# Altium schematic sheet SPI_USB_SUPPLY_PWM.SchDoc
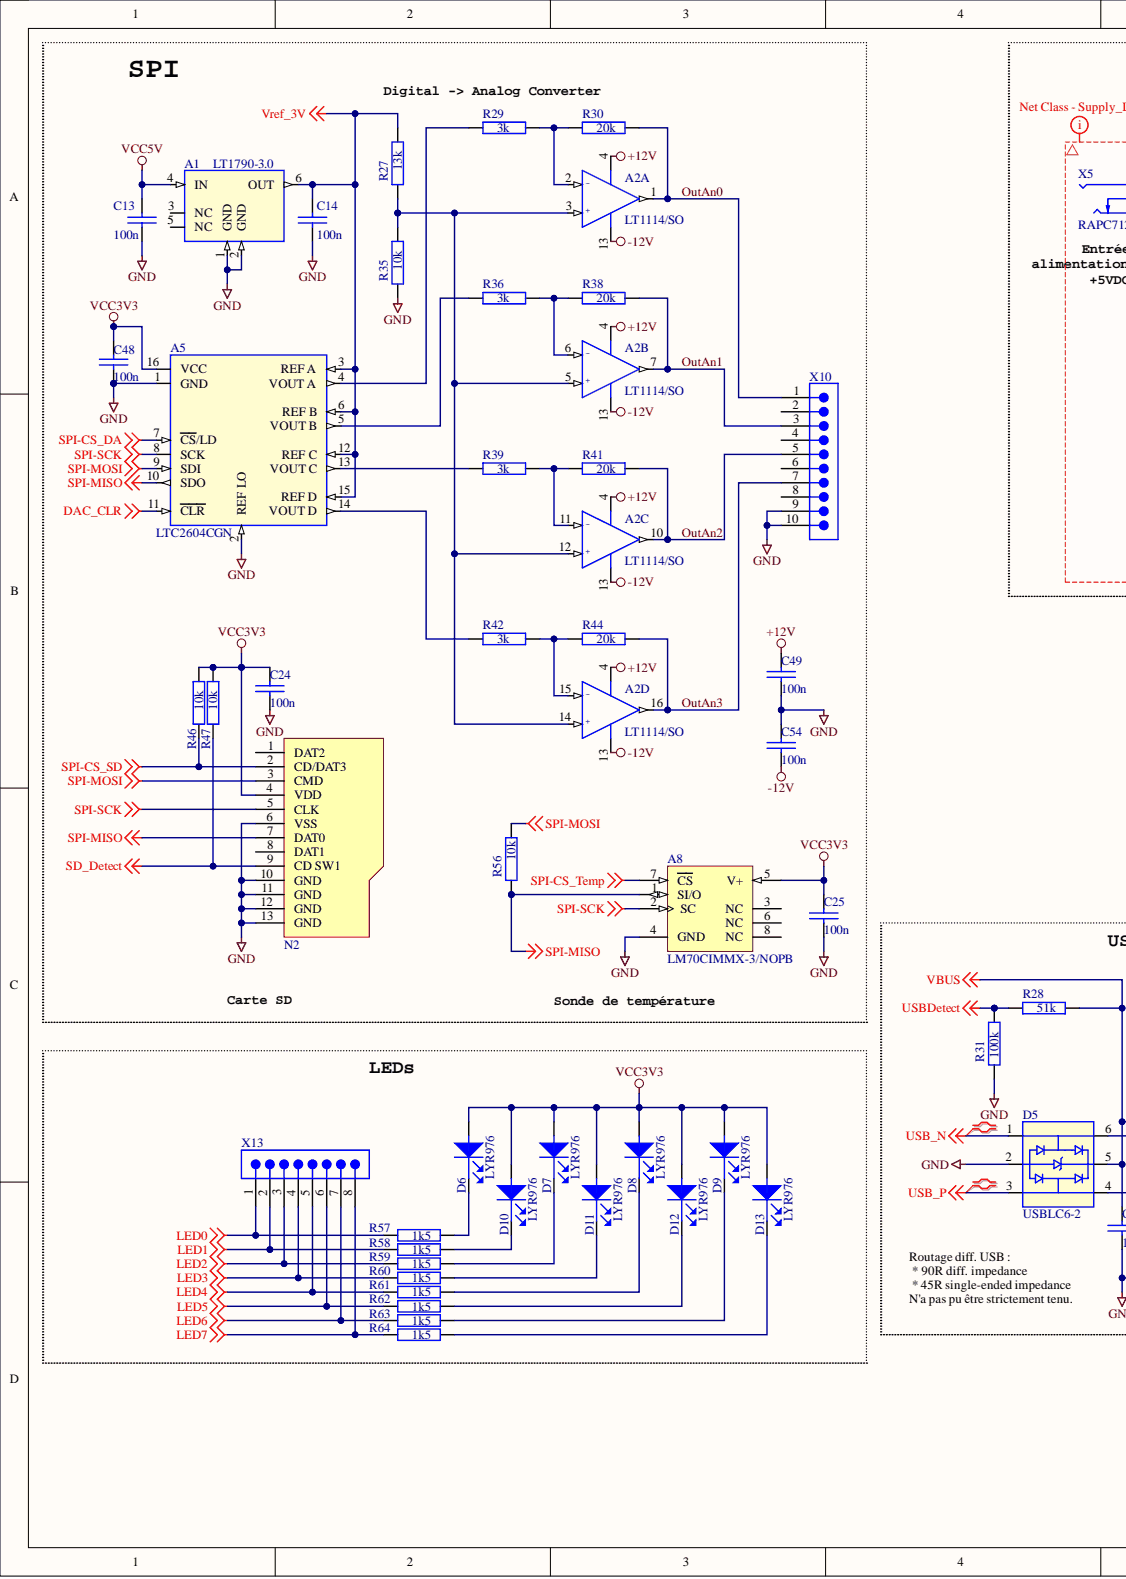
[diagram p0: SPI_USB_SUPPLY_PWM.SchDoc, left]
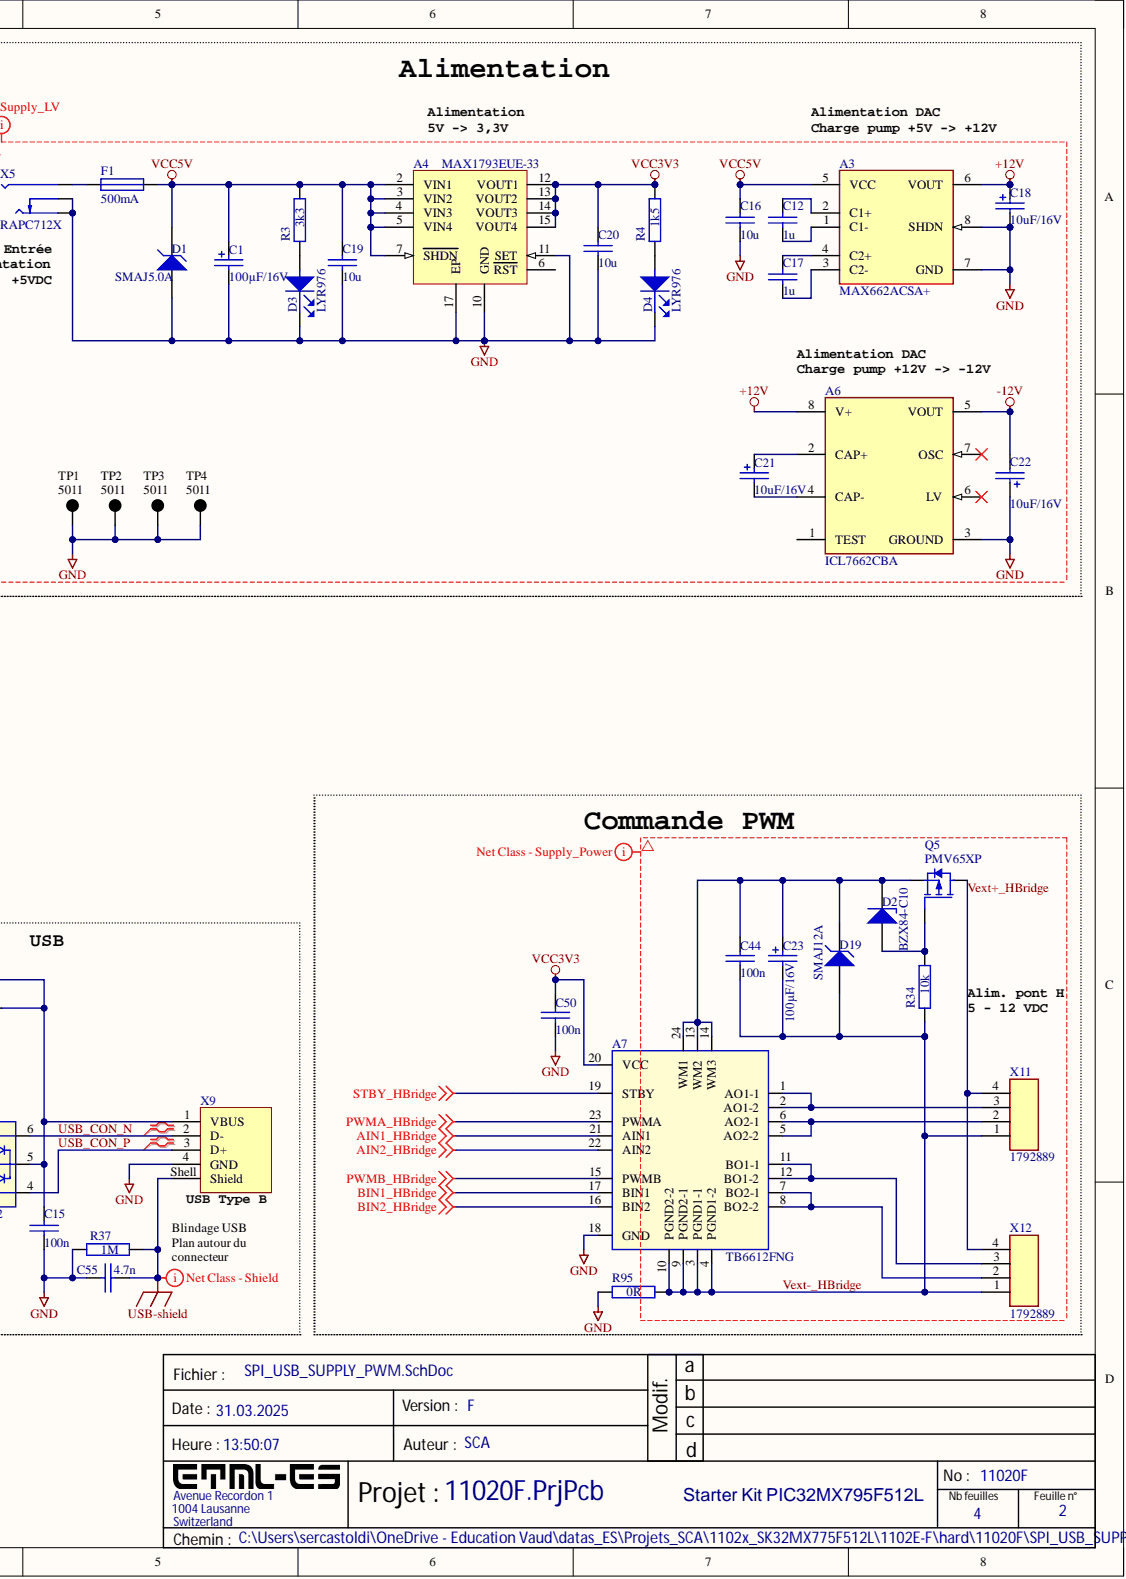
[diagram p1: SPI_USB_SUPPLY_PWM.SchDoc, right]

## Parts
A1  LT1790-3.0  [STM-SOT23-6L_V]
A2  LT1114/SO  [SOIC-16]  (parts A,B,C,D)
A3  MAX662ACSA+  [FP-S8-4-IPC_A]
A4  MAX1793EUE-33  [TSOP65P640X110_HS-17N]
A5  LTC2604CGN  [FP-GN-16-05-08-1641-IPC_A]
A6  ICL7662CBA  [FP-S8-4-IPC_A]
A7  TB6612FNG  [TOSH-SSOP-24_M]
A8  LM70CIMMX-3/NOPB  [DGK0008A_M]
C1  100µF/16V  [FP-FCE-MFG]
C12  1u  [CAPC0805(2012)145_N]
C13  100n  [CAPC0805(2012)145_N]
C14  100n  [CAPC0805(2012)145_N]
C15  100n  [CAPC0805(2012)145_N]
C16  10u  [CAPC0805(2012)145_N]
C17  1u  [CAPC0805(2012)145_N]
C18  10uF/16V  [FP-FCB-MFG]
C19  10u  [CAPC0805(2012)145_N]
C20  10u  [CAPC0805(2012)145_N]
C21  10uF/16V  [FP-FCB-MFG]
C22  10uF/16V  [FP-FCB-MFG]
C23  100µF/16V  [FP-FCE-MFG]
C24  100n  [CAPC0805(2012)145_N]
C25  100n  [CAPC0805(2012)145_N]
C44  100n  [CAPC0805(2012)145_N]
C48  100n  [CAPC0805(2012)145_N]
C49  100n  [CAPC0805(2012)145_N]
C50  100n  [CAPC0805(2012)145_N]
C54  100n  [CAPC0805(2012)145_N]
C55  4.7n  [CAPC0805(2012)145_N]
D1  SMAJ5.0A  [WE-TVSP_DO-214AC_Unidirectional]
D2  BZX84-C10  [FP-SOT23-IPC_A]
D3  LYR976  [LED_0805]
D4  LYR976  [LED_0805]
D5  USBLC6-2  [STM-SOT23-6L_V]
D6  LYR976  [LED_0805]
D7  LYR976  [LED_0805]
D8  LYR976  [LED_0805]
D9  LYR976  [LED_0805]
D10  LYR976  [LED_0805]
D11  LYR976  [LED_0805]
D12  LYR976  [LED_0805]
D13  LYR976  [LED_0805]
D19  SMAJ12A  [WE-TVSP_DO-214AC_Unidirectional]
F1  500mA  [FP-MF-SM050-2-MFG]
N2  693071020811  [693071020811]  (value not drawn)
Q5  PMV65XP  [FP-SOT23-IPC_A]
R3  3k3  [RESC0805(2012)_N]
R4  1k5  [RESC0805(2012)_N]
R27  13k  [RESC0805(2012)_N]
R28  51k  [RESC0805(2012)_N]
R29  3k  [RESC0805(2012)_N]
R30  20k  [RESC0805(2012)_N]
R31  100k  [RESC0805(2012)_N]
R34  10k  [RESC0805(2012)_N]
R35  10k  [RESC0805(2012)_N]
R36  3k  [RESC0805(2012)_N]
R37  1M  [RESC0805(2012)_N]
R38  20k  [RESC0805(2012)_N]
R39  3k  [RESC0805(2012)_N]
R41  20k  [RESC0805(2012)_N]
R42  3k  [RESC0805(2012)_N]
R44  20k  [RESC0805(2012)_N]
R46  10k  [RESC0805(2012)_N]
R47  10k  [RESC0805(2012)_N]
R56  10k  [RESC0805(2012)_N]
R57  1k5  [RESC0805(2012)_N]
R58  1k5  [RESC0805(2012)_N]
R59  1k5  [RESC0805(2012)_N]
R60  1k5  [RESC0805(2012)_N]
R61  1k5  [RESC0805(2012)_N]
R62  1k5  [RESC0805(2012)_N]
R63  1k5  [RESC0805(2012)_N]
R64  1k5  [RESC0805(2012)_N]
R95  0R  [RESC0805(2012)_N]
TP1  5011  [KSTN-5011_V]
TP2  5011  [KSTN-5011_V]
TP3  5011  [KSTN-5011_V]
TP4  5011  [KSTN-5011_V]
X5  RAPC712X  [SWITCHCRAFT_RAPC712X]
X9  Interface Type: Type B  [61400416121]  (hidden comment: 61400416121)
X10  61301011121  [61301011121]  (value not drawn)
X11  1792889  [1792889_CustomES]
X12  1792889  [1792889_CustomES]
X13  61300811121  [61300811121]  (value not drawn)

## Nets
+12V: A2.4 A3.6(VOUT) A6.8(V+) C18.2 C49.1
-12V: A2.13 A6.5(VOUT) C22.1 C54.2
AIN1_HBridge: A7.21(AIN1)
AIN2_HBridge: A7.22(AIN2)
BIN1_HBridge: A7.17(BIN1)
BIN2_HBridge: A7.16(BIN2)
DAC_CLR: A5.11(CLR)
GND: A1.1(GND) A1.2(GND) A3.7(GND) A3.8(SHDN) A4.10(GND) A4.11(SET) A4.17(EP) A5.1(GND) A5.2(REF_LO) A6.3(GROUND) A7.18(GND) A8.4(GND) C1.1 C13.2 C14.2 C15.2 C16.2 C18.1 C19.2 C20.2 C22.2 C24.2 C25.1 C48.2 C49.2 C50.2 C54.1 C55.1 D1.2 D3.1 D4.1 D5.2 N2.6(VSS) N2.10(GND) N2.11(GND) N2.12(GND) N2.13(GND) R31.1 R35.2 R37.1 R95.1 TP1.1 TP2.1 TP3.1 TP4.1 X5.SHUNT X5.SLEEVE X9.4(GND) X10.9 X10.10
LED0: R57.2 X13.1
LED1: R58.2 X13.2
LED2: R59.2 X13.3
LED3: R60.2 X13.4
LED4: R61.2 X13.5
LED5: R62.2 X13.6
LED6: R63.2 X13.7
LED7: R64.2 X13.8
PWMA_HBridge: A7.23(PWMA)
PWMB_HBridge: A7.15(PWMB)
SD_Detect: N2.9(CD_SW1) R47.2
SPI-CS_DA: A5.7(CS/LD)
SPI-CS_SD: N2.2(CD/DAT3) R46.2
SPI-CS_Temp: A8.7(CS)
SPI-MISO: A5.10(SDO) A8.1(SI/O) N2.7(DAT0) R56.1
SPI-MOSI: A5.9(SDI) N2.3(CMD) R56.2
SPI-SCK: A5.8(SCK) A8.2(SC) N2.5(CLK)
STBY_HBridge: A7.19(STBY)
USB-shield: C55.2 R37.2 X9.Shell(Shield)
USB_N: D5.1
USB_P: D5.3
USBDetect: R28.1 R31.2
VBUS: C15.1 D5.5 R28.2 X9.1(VBUS)
VCC3V3: A4.12(VOUT1) A4.13(VOUT2) A4.14(VOUT3) A4.15(VOUT4) A5.16(VCC) A7.20(VCC) A8.5(V+) C20.1 C24.1 C25.2 C48.1 C50.1 D6.2 D7.2 D8.2 D9.2 D10.2 D11.2 D12.2 D13.2 N2.4(VDD) R4.2 R46.1 R47.1
VCC5V: A1.4(IN) A3.5(VCC) A4.2(VIN1) A4.3(VIN2) A4.4(VIN3) A4.5(VIN4) A4.7(SHDN) C1.2 C13.1 C16.1 C19.1 D1.1 F1.1 R3.2
Vref_3V: A1.6(OUT) A5.3(REF_A) A5.6(REF_B) A5.12(REF_C) A5.15(REF_D) C14.1 R27.1
OutAn0: A2.1 R30.2 X10.1
OutAn1: A2.7 R38.2 X10.3
OutAn2: A2.10 R41.2 X10.5
OutAn3: A2.16 R44.2 X10.7
USB_CON_N: D5.6 X9.2(D-)
USB_CON_P: D5.4 X9.3(D+)
Vext+_HBridge: Q5.3 X11.4 X12.4
Vext-_HBridge: A7.3(PGND1-1) A7.4(PGND1-2) A7.9(PGND2-1) A7.10(PGND2-2) C23.1 C44.2 D19.2 R34.2 R95.2 X11.1 X12.1
NetA2_2: A2.2(-) R29.2 R30.1
NetA2_3: A2.3(+) A2.5(+) A2.12(+) A2.14(+) R27.2 R35.1
NetA2_6: A2.6(-) R36.2 R38.1
NetA2_11: A2.11(-) R39.2 R41.1
NetA2_15: A2.15(-) R42.2 R44.1
NetA3_1: A3.1(C1-) C12.1
NetA3_2: A3.2(C1+) C12.2
NetA3_3: A3.3(C2-) C17.1
NetA3_4: A3.4(C2+) C17.2
NetA5_4: A5.4(VOUT_A) R29.1
NetA5_5: A5.5(VOUT_B) R36.1
NetA5_13: A5.13(VOUT_C) R39.1
NetA5_14: A5.14(VOUT_D) R42.1
NetA6_2: A6.2(CAP+) C21.2
NetA6_4: A6.4(CAP-) C21.1
NetA7_1: A7.1(AO1-1) A7.2(AO1-2) X11.3
NetA7_5: A7.5(AO2-2) A7.6(AO2-1) X11.2
NetA7_7: A7.7(BO2-1) A7.8(BO2-2) X12.2
NetA7_11: A7.11(BO1-1) A7.12(BO1-2) X12.3
NetA7_13: A7.13(WM2) A7.14(WM3) A7.24(WM1) C23.2 C44.1 D2.3 D19.1 Q5.2
NetD2_1: D2.1 Q5.1 R34.1
NetD3_2: D3.2 R3.1
NetD4_2: D4.2 R4.1
NetD6_1: D6.1 R57.1
NetD7_1: D7.1 R59.1
NetD8_1: D8.1 R61.1
NetD9_1: D9.1 R63.1
NetD10_1: D10.1 R58.1
NetD11_1: D11.1 R60.1
NetD12_1: D12.1 R62.1
NetD13_1: D13.1 R64.1
NetF1_2: F1.2 X5.PIN

Not connected: A1 3(NC) 5(NC); A4 6(RST); A6 1(TEST) 6(LV) 7(OSC); A8 3(NC) 6(NC) 8(NC); N2 1(DAT2) 8(DAT1); X10 2 4 6 8

## Library data of the parts
A1 = LT1790: Component Kind: Standard; Library Name: 11020E.SCHLIB
A3 = CMP-05722-000026-1: IC REG CHARG PUMP 12V 30MA 8SOIC; Manufacturer: Maxim Integrated; Max Power Dissipation: 471mW; Max Supply Voltage: 5.5V; Min Supply Voltage: 4.5V; Nominal Supply Current: 185uA; Number Of Outputs: 1; Output Type: Other; Standoff Height: 0.1mm; Switching Frequency: 500kHz; Switching Topology: Charge Pump; Automotive: No; Category: IC; Component Kind: Standard; ComponentLink2Description: Datasheet; ComponentLink2URL: https://datasheets.maximintegrated.com/en/ds/MAX662A.pdf; Device Class L1: Integrated Circuits (ICs); Device Class L2: Power Management ICs; Device Class L3: Voltage Regulators - Switching; Digikey Description: IC REG CHARG PUMP 12V 30MA 8SOIC; Footprint Url: https://pdfserv.maximintegrated.com/land_patterns/90-0096.PDF; Height: 1.75mm; Lead Free: Yes; Library Name: Altium Content Vault; Manufacturer Part Number: MAX662ACSA+; Mouser Description: Voltage Regulators - Switching Regulators 12V 30mA Flash Programming Supply; Octopart: https://octopart.com/max662acsa%2B-maxim+integrated-39398572; Output Current: 30mA; Output Voltage: 12V; Package: S8-5; RoHS: TRUE; Temperature: 0°C to +70°C; Temperature Range High: +70°C; Temperature Range Low: 0°C
A4 = MAX1793EUE-33: MAX1793 linear regulator 3.3V; Code_JEDEC: MO-178-AB; Component Kind: Standard; ComponentLink1Description: Manufacturer URL; ComponentLink1URL: http://www.maxim-ic.com; ComponentLink2Description: Datasheet; ComponentLink2URL: https://datasheets.maximintegrated.com/en/ds/MAX1793.pdf; ComponentLink3Description: Package Specification; Library Name: KitArmM0.SchLib; PackageDescription: TSSOP16+exposed pad; PackageReference: http://pdfserv.maxim-ic.com/package_dwgs/21-0108.PDF; Supplier: Digikey,Stock ES; Supplier min. qty: 1; Supplier Ref.: MAX1793EUE33+-ND; Supplier unit price: 3.88; Value: MAX1793EUE-33
A5 = LTC2604: Integral Nonlinearity (INL): 64LSB; Interface: Serial; Max Power Dissipation: 10mW; Max Supply Voltage: 5.5V; Min Supply Voltage: 2.5V; Nominal Supply Current: 1.3mA; Number of Bits: 16; Number of Channels: 4; Operating Supply Voltage: 5V; Output Polarity: Unipolar; Output Type: Voltage; Resolution: 16b; Settling Time: 10us; Supply Type: Single; Case/Package: SSOP; Component Kind: Standard; Height: 1.5mm; Lead Free: Lead Free; Length: 4.98mm; Max Operating Temperature: 70°C; Min Operating Temperature: 0°C; Mount: Surface Mount; Number of Converters: 4; Number of DAC Channels: 4; Number of Pins: 16; Package Quantity: 100; Packaging: Rail/Tube; Polarity: Unipolar; Width: 3.99mm
A6 = CMP-05722-000092-1: IC REG CHARGE PUMP INV 8SOIC; Manufacturer: Maxim Integrated; Manufacturer Part Number: ICL7662CBA+T; Max Power Dissipation: 500mW; Max Supply Voltage: 20V; Min Supply Voltage: 4.5V; Negative Voltage Available: true; Nominal Supply Current: 20-250uA; Number Of Outputs: 1; Output Current: 20mA; Output Type: Fixed; Output Voltage: -20/+40V; Standoff Height: 0.1mm; Switching Frequency: 10kHz; Automotive: No; Category: IC; Component Kind: Standard; ComponentLink2Description: Datasheet; ComponentLink2URL: https://datasheets.maximintegrated.com/en/ds/ICL7662-Si7661.pdf; Device Class L1: Integrated Circuits (ICs); Device Class L2: Power Management ICs; Device Class L3: Voltage Regulators - Switching; Digikey Description: IC REG CHARGE PUMP INV 8SOIC; Footprint Url: https://pdfserv.maximintegrated.com/land_patterns/90-0096.PDF; Height: 1.75mm; Lead Free: Yes; Library Name: Altium Content Vault; Mouser Description: Switching Voltage Regulators CMOS Voltage Converter; Octopart: https://octopart.com/icl7662cba%2Bt-maxim+integrated-39621882; Package: S8-4; RoHS: TRUE; Switching Topology: Charge Pump; Temperature: 0°C to +70°C; Temperature Range High: +70°C; Temperature Range Low: 0°C
A8 = SEN-TEMP-LM70CIMM-8: SPI/10-Bit plus Sign Digital Temperature Sensor, 8-pin Mini SOIC, Pb-Free; Manufacturer: Texas Instruments; CompType: Temp Sensor IC; PackageTopMarking: ZXTT*T04C; PowerWise: Y; Code_JEDEC: MO-187-AA; Component Kind: Standard; ComponentLink1Description: Datasheet URL; ComponentLink1URL: http://www.ti.com/general/docs/lit/getliterature.tsp?genericPartNumber=LM70&fileType=pdf; Library Name: 11020E.SCHLIB; PackageDescription: MINI SOIC, .118 x .118 x .034in, 8 Lead, .0256in Pitch; PackageReference: DGK0008A; PinCount: 8; RoHS: Y
C1 C23 = C_POL: EEE-FC1C101P Aluminum Electrolytic Capacitor, 100 uF, 16 V, ± 20%, 1000 hours @ 105°C, Radial Can - SMD; NexarPartId: 39481103; Capacitance: 100µF; Dielectric Material: Aluminium; ESR (Equivalent Series Resistance): 400mΩ; Lead Length: 3.4mm; Leakage Current: 3µA; Polarity: Polar; Ripple Current: 230mA; Voltage: 16V; Case/Package: Radial; Component Kind: Standard; Construction: Cylindrical; Contact Plating: Tin; Diameter: 8mm; Height: 6.2mm; Height - Seated (Max): 6.1976mm; Impedance: 400mΩ; Lead Free: Lead Free; Lead Pitch: 2.2mm; Length: 6.5mm; Library Name: 11020E.SCHLIB; Life (Hours): 1000hours; Lifecycle Status: Production; Material: Aluminium; Max Operating Temperature: 105°C; Min Operating Temperature: -40°C; Mount: PCB, Surface Mount; Number of Pins: 2; Packaging: Cut Tape; Radiation Hardening: No; REACH SVHC: No SVHC; Ripple Current (AC): 230mA; RoHS: Compliant; Schedule B: 8532220020, 8532220020; Supplier: Stock ES; Termination: SMD/SMT; Tolerance: 20%; Voltage Rating: 16V; Voltage Rating (AC): 16V; Voltage Rating (DC): 16V; Width: 8.3mm
C12-C17 C19 C20 C24 C25 C44 C48-C50 C54 C55 = C: Component Kind: Standard
  C12 C17: Value: 1u
  C13-C15 C24 C25 C44 C48-C50 C54: Value: 100n
  C16 C19 C20: Value: 10u
  C55: Value: 4.7n
C18 C21 C22 = C_POL: EEE-FC1C100R CAP ALUM 10UF 20% 16V SMD; Dielectric Material: Aluminium; ESR (Equivalent Series Resistance): 3R; Lead Length: 1.8mm; Leakage Current: 3uA; Life (Hours): 1000Hour; Ripple Current: 60mA; Capacitance: 10uF; Case/Package: Radial; Component Kind: Standard; Construction: Cylindrical; Contact Plating: Tin; Diameter: 4mm; Height: 5.4mm; Height - Seated (Max): 0.213inch; Impedance: 3R; Lead Free: Lead Free; Lead Pitch: 1mm; Length: 5.5mm; Library Name: 11020E.SCHLIB; Material: Aluminium; Max Operating Temperature: 105°C; Min Operating Temperature: -40°C; Mount: Surface Mount; Number of Pins: 2; Package Quantity: 2000; Packaging: Tape and Reel; Radiation Hardening: No; Ratings: AEC-Q200; Reach SVHC Compliant: Yes; Ripple Current (AC): 60mA; RoHS Compliant: Yes; Series: FC; Supplier: Stock ES; Termination: SMD/SMT; Tolerance: 20%; Voltage: 16V; Voltage Rating: 16V; Voltage Rating (DC): 16V; Width: 4.3mm
D1 = 824500500: WE-TVSP SMT Power TVS Diode, DO-214AC, Unidirectional, 400W, 5VDC; Manufacturer: Wurth Elektronik; (Reverse) Breakdown Voltage: 6.7V; (Reverse) Peak Pulse Current: 43.5A; Clamping Voltage: 9.2V; Operating Voltage (DC): 5V; Case/Size Code: DO-214AC; Category: Surge Protection; Component Kind: Standard; ComponentLink1Description: Website Link; ComponentLink1URL: https://www.we-online.com/redexpert/article/824500500?ad; ComponentLink2Description: Datasheet Link; ComponentLink2URL: https://www.we-online.com/redexpert/spec/824500500?ad; Height: 2.25mm; Length: 4.24mm; Library Name: Altium_WE-TVSP (rev22b).IntLib; Manufacturer Part Number: 824500500; Match Code: WE-TVSP; Mount: Surface Mount; Operating Temperature Max: 150°C; Operating Temperature Min: -65°C; Packaging: Tape and Reel; Polarity: Unidirectional; Power Dissipation: 400W; Width: 2.67mm
D2 = D_ZENER: Zener Diode 10V 250mW ±5% Surface Mount TO-236AB; Manufacturer: Nexperia; Manufacturer Part Number: BZX84-C10,215; PartNumber: LGR971-KN-1; Automotive Grade: Grade 0; Color: Green; Forward Current: 200mA; Max Junction Temp: +150°C; Max Reverse Leakage Current: 0.2uA; Max Zener Voltage: 10.6V; Reverse Voltage: 7V; Value: LGR971-KN-1; Zener Impedance: 8Ω; Automotive: Yes; Category: Diode; Component Kind: Standard; ComponentLink1Description: Manufacturer URL; ComponentLink2Description: Datasheet; ComponentLink2URL: https://assets.nexperia.com/documents/data-sheet/BZX84_SER.pdf; Device Class L1: Discrete Semiconductors; Device Class L2: Diodes; Device Class L3: Zener Diodes; Digikey Description: DIODE ZENER 10V 250MW SOT23; Footprint Url: https://assets.nexperia.com/documents/outline-drawing/SOT23.pdf; Height: 1.1mm; Ipc Land Pattern Name: SOT95P230X100-3; Lead Free: Yes; Library Name: 11020E.SCHLIB; Mouser Description: Diode Zener Single 10 Volt 5% 250m Watt Automotive 3-Pin SOT-23; Nom Zener Voltage: 10V; Octopart: https://octopart.com/bzx84-c10%2C215-nexperia-78740221; Package: SOT23; PackageReference: 0805_A; Power Dissipation: 250mW; RoHS: TRUE; Supplier: Stock ES; Temperature: -65°C to +150°C; Temperature Range High: +150°C; Temperature Range Low: -65°C
D3 D4 D6-D13 = LED_0: LED YELLOW DIFFUSED 0805 SMD; Lens Color: White; Lens Style: Flat; Case Code (Imperial): 0805; Case Code (Metric): 2012; Case/Package: 0805; Color: Yellow; Component Kind: Standard; Illumination Color: Yellow; Library Name: 11020E.SCHLIB; Mount: Surface Mount
D19 = 824500121: WE-TVSP SMT Power TVS Diode, DO-214AC, Unidirectional, 400W, 12VDC; Manufacturer: Wurth Elektronik; (Reverse) Breakdown Voltage: 14V; (Reverse) Peak Pulse Current: 20.1A; Clamping Voltage: 19.9V; Operating Voltage (DC): 12V; Case/Size Code: DO-214AC; Category: Surge Protection; Component Kind: Standard; ComponentLink1Description: Website Link; ComponentLink1URL: https://www.we-online.com/redexpert/article/824500121?ad; ComponentLink2Description: Datasheet Link; ComponentLink2URL: https://www.we-online.com/redexpert/spec/824500121?ad; Height: 2.25mm; Length: 4.24mm; Library Name: Altium_WE-TVSP (rev22b).IntLib; Manufacturer Part Number: 824500121; Match Code: WE-TVSP; Mount: Surface Mount; Operating Temperature Max: 150°C; Operating Temperature Min: -65°C; Packaging: Tape and Reel; Polarity: Unidirectional; Power Dissipation: 400W; Width: 2.67mm
F1 = F: MF-SM050-2 / PTC RESET FUSE 60V 500MA 2SMD; Manufacturer: Bourns; Automotive Grade: Grade 3; Current Rating: 40A; Hold Current: 0.5A; Resistance: 0.35-1.4Ω; Standoff Height: 0.66mm; Trip Current: 1A; Trip Time: 4s; Automotive: Yes; Category: Fuse; Component Kind: Standard; ComponentLink2Description: Datasheet; ComponentLink2URL: https://www.bourns.com/docs/Product-Datasheets/mfsm.pdf; Device Class L1: Circuit Protection; Device Class L2: PTC Resettable Fuses; Device Class L3: unset; Digikey Description: PTC RESET FUSE 60V 500MA 2SMD; Height: 3.18mm; Lead Free: Yes; Library Name: 11020E.SCHLIB; Manufacturer Part Number: MF-SM050-2; Mouser Description: PTC Resettable Fuse 0.5A(hold) 1A(trip) 60V 40A 1.7W 4s 0.35Ohm SMD Solder Pad 7.98 X 5.44 X 3.18mm T/R; Octopart: https://octopart.com/mf-sm050-2-bourns-37815; Package: MF-SM050-2; RoHS: TRUE; Temperature: -40°C to +85°C; Temperature Range High: +85°C; Temperature Range Low: -40°C; Value: 500mA; Voltage Rating: 60V
N2 = 693071020811: WR-CRD Micro SD Card Connector Push & Push; Manufacturer: Wurth Elektronik; Contact Resistance: 100mOhm; Gender: Socket; Rated Current: 0.5A; Withstanding Voltage: 500VAC; Working Voltage: 30VAC; Category: Input/Output Connectors; Component Kind: Standard; ComponentLink1Description: Website Link; ComponentLink1URL: https://www.we-online.com/redexpert/article/693071020811?ad; ComponentLink2Description: Datasheet Link; ComponentLink2URL: https://www.we-online.com/redexpert/spec/693071020811?ad; Library Name: Altium_WR-CRD (rev23b).IntLib; Manufacturer Part Number: 693071020811; Match Code: WR-CRD; Mount: Surface Mount; Number of Pins: 8; Operating Temperature Max: 85°C; Operating Temperature Min: -40°C; Packaging: Tape and Reel; Type: Push & Push
Q5 = PEMOS-GSD-3_A: Single P-Channel Trench MOSFET, -20 V, -55 to 150 degC, 3-Pin SOT23, Tape and Reel; Manufacturer: NXP Semiconductors; PartNumber: PMV65XP,215; Channel Type: P; Ciss [typ] (pF): 744; Continuous Drain Current Id: 1.2A; Coss [typ] (pF): 65; Current Temperature: 25degC; Drain Source Voltage Vds: 20V; External Depth: 2.5mm; External Length / Height: 1.12mm; External Width: 3.05mm; Junction Temperature Tj Min: -55degC; No. of Pins: 3; No. of Transistors: 1; On Resistance Rds(on): 250mohm; Packing: Tape & Reel; Power Dissipation Pd: 540mW; Pulse Current Idm: 7.4A; Rds(on) Test Voltage Vgs: 4.5V; Reel Quantity: 3000; SMD Marking: 1A; SVHC: NoSVHC(19-Dec-2012); Threshold Voltage Vgs Typ: 700mV; Transistor Case Style: SOT-23; Transistor Polarity: NChannel; VGSth [typ] (V): -0.65; Code_JEDEC: TO-236AB; Component Kind: Standard; ComponentLink1Description: Manufacturer URL; ComponentLink1URL: http://www.nxp.com/; ComponentLink2Description: Datasheet; ComponentLink2URL: http://www.nxp.com/documents/data_sheet/PMV65XP.pdf; ComponentLink3Description: Package Specification; ComponentLink3URL: http://www.nxp.com/documents/outline_drawing/sot023_po.pdf; Current Id Max: 1.2A; Datasheet URL: https://datasheet.ciiva.com/29326/162826-da-01-en-irlml-2402tr-29326791.pdf; Datasheet Version: Jan-03; DatasheetVersion: Rev. 2; Full Power Rating Temperature: 25degC; Library Name: 11020E.SCHLIB; Manufacturer URL: http://internationalrectifier.com/; Mounting Technology: Surface Mount; Operating Temperature Range: -55degCto+150degC; Package / Case: SOT-23; PackageDescription: 3-Pin Small Outline Transistor Package, Body 2.9 x 1.3 mm, Pitch 0.95 mm; PackageReference: SOT23; PackageVersion: 06-03-16, 03/2006; Tape Width: 8mm; Termination Type: SMD; VDS [max] (V): -20; Voltage Vds Typ: 20V; Voltage Vgs Max: 700mV; Voltage Vgs Rds on Measurement: 4.5V; Voltage Vgs th Min: 700mV
R3 R4 R27-R31 R34-R39 R41 R42 R44 R46 R47 R56-R64 R95 = R: Component Kind: Standard
  R3: Value: 3k3
  R4 R57-R64: Value: 1k5
  R27: Value: 13k
  R28: Value: 51k
  R29 R36 R39 R42: Value: 3k
  R30 R38 R41 R44: Value: 20k
  R31: Value: 100k
  R34 R35 R46 R47 R56: Value: 10k
  R37: Value: 1M
  R95: Value: 0R
TP1-TP4 = CMP-1672-00003-4: Test Point, Black, Through Hole, RoHS, Bulk; Manufacturer: Keystone; Colour: Black; Component Kind: Standard; ComponentLink1Description: Manufacturer URL; ComponentLink1URL: http://www.keyelco.com/; ComponentLink2Description: Datasheet; ComponentLink2URL: http://www.keyelco.com/product-pdf.cfm?p=1320; Contact Material: Bronze; Contact Plating: Silver; DatasheetVersion: Rev. F, 12/2013; Height: 8.12 mm; Library Name: Altium Content Vault; Mount: Through Hole; Mounting Technology: Through Hole; Package Quantity: 100; PackageDescription: 1-Pin Through Hole Device, Dia 3.17 mm; PackageReference: 5011; Packaging: Bulk; Pins: 1; REACH SVHC: No SVHC; RoHSCompliant: true
X5 = RAPC712X: Power Barrel Connector Jack 2.50mm ID (0.098), 5.50mm OD (0.217) Through Hole, Right Angle; MF: Switchcraft; Availability: In Stock; Check_prices: https://www.snapeda.com/parts/RAPC712X/Switchcraft+Inc./view-part/?ref=eda; Component Kind: Standard; Library Name: 11020E.SCHLIB; MP: RAPC712X; Package: None; Price: None; Purchase-URL: https://pricing.snapeda.com/search/part/RAPC712X/?ref=eda; SnapEDA_Link: https://www.snapeda.com/parts/RAPC712X/Switchcraft+Inc./view-part/?ref=snap
X9 = 61400416121: WR-COM USB 2.0 Horizontal THT; Manufacturer: Wurth Elektronik; Gender: Receptacle; Match Code: WR-USB; Number of Ports: 1; Rated Current: 1.5A; Working Voltage: 30V (AC); Application: USB 2.0; Category: Input/Output Connectors; Component Kind: Standard; ComponentLink1Description: Website Link; ComponentLink1URL: https://www.we-online.com/redexpert/article/61400416121?ad; ComponentLink2Description: Datasheet Link; ComponentLink2URL: https://www.we-online.com/redexpert/spec/61400416121?ad; Library Name: Altium_WR-USB (rev23b).IntLib; Manufacturer Part Number: 61400416121; Mount: Through Hole; Number of Pins: 4; Operating Temperature Max: 105°C; Operating Temperature Min: -40°C; Orientation: Horizontal; Packaging: Tray
X10 = 61301011121: WR-PHD Pin Header, THT, Vertical, pitch 2.54mm, 1 Row, 10P; Manufacturer: Wurth Elektronik; Contact Resistance: 20mΩ; Rated Current: 3A; Withstanding Voltage: 500V; Working Voltage: 250V; Category: Board-to-Board Connectors; Component Kind: Standard; ComponentLink1Description: Website Link; ComponentLink1URL: https://www.we-online.com/redexpert/article/61301011121?ad; ComponentLink2Description: Datasheet Link; ComponentLink2URL: https://www.we-online.com/redexpert/spec/61301011121?ad; Gender: Pin Header; Library Name: Altium_WR-PHD (rev22b).IntLib; Manufacturer Part Number: 61301011121; Match Code: WR-PHD; Mount: Through Hole; Number of Pins: 10; Number of Rows: 1; Operating Temperature Max: 105°C; Operating Temperature Min: -40°C; Orientation: Vertical; Packaging: Bag; Pitch: 2.54mm
X11 X12 = 1792889: Connector; Manufacturer_Name: Phoenix Contact; Arrow Part Number: 1792889; Arrow Price/Stock: https://www.arrow.com/en/products/1792889/phoenix-contact?region=nac; Component Kind: Standard; Datasheet Link: https://componentsearchengine.com/Datasheets/2/1792889.pdf; Height: 13.6mm; Library Name: 11020E.SCHLIB; Manufacturer_Part_Number: 1792889; Mouser Part Number: 651-1792889; Mouser Price/Stock: https://www.mouser.co.uk/ProductDetail/Phoenix-Contact/1792889?qs=YjJcVSlHJPVcGNE9t5gd%252Bw%3D%3D
X13 = 61300811121: WR-PHD Pin Header, THT, Vertical, pitch 2.54mm, 1 Row, 8P; Manufacturer: Wurth Elektronik; Contact Resistance: 20mΩ; Rated Current: 3A; Withstanding Voltage: 500V; Working Voltage: 250V; Category: Board-to-Board Connectors; Component Kind: Standard; ComponentLink1Description: Website Link; ComponentLink1URL: https://www.we-online.com/redexpert/article/61300811121?ad; ComponentLink2Description: Datasheet Link; ComponentLink2URL: https://www.we-online.com/redexpert/spec/61300811121?ad; Gender: Pin Header; Library Name: Altium_WR-PHD (rev22b).IntLib; Manufacturer Part Number: 61300811121; Match Code: WR-PHD; Mount: Through Hole; Number of Pins: 8; Number of Rows: 1; Operating Temperature Max: 105°C; Operating Temperature Min: -40°C; Orientation: Vertical; Packaging: Bag; Pitch: 2.54mm
A2 = LT1114/SO: Component Kind: Standard
A7 = TB6612FNG: Component Kind: Standard
D5 = USBLC6-2: Component Kind: Standard
